# Altium schematic sheet Dental Sensor.SchDoc("Dental Sensor")
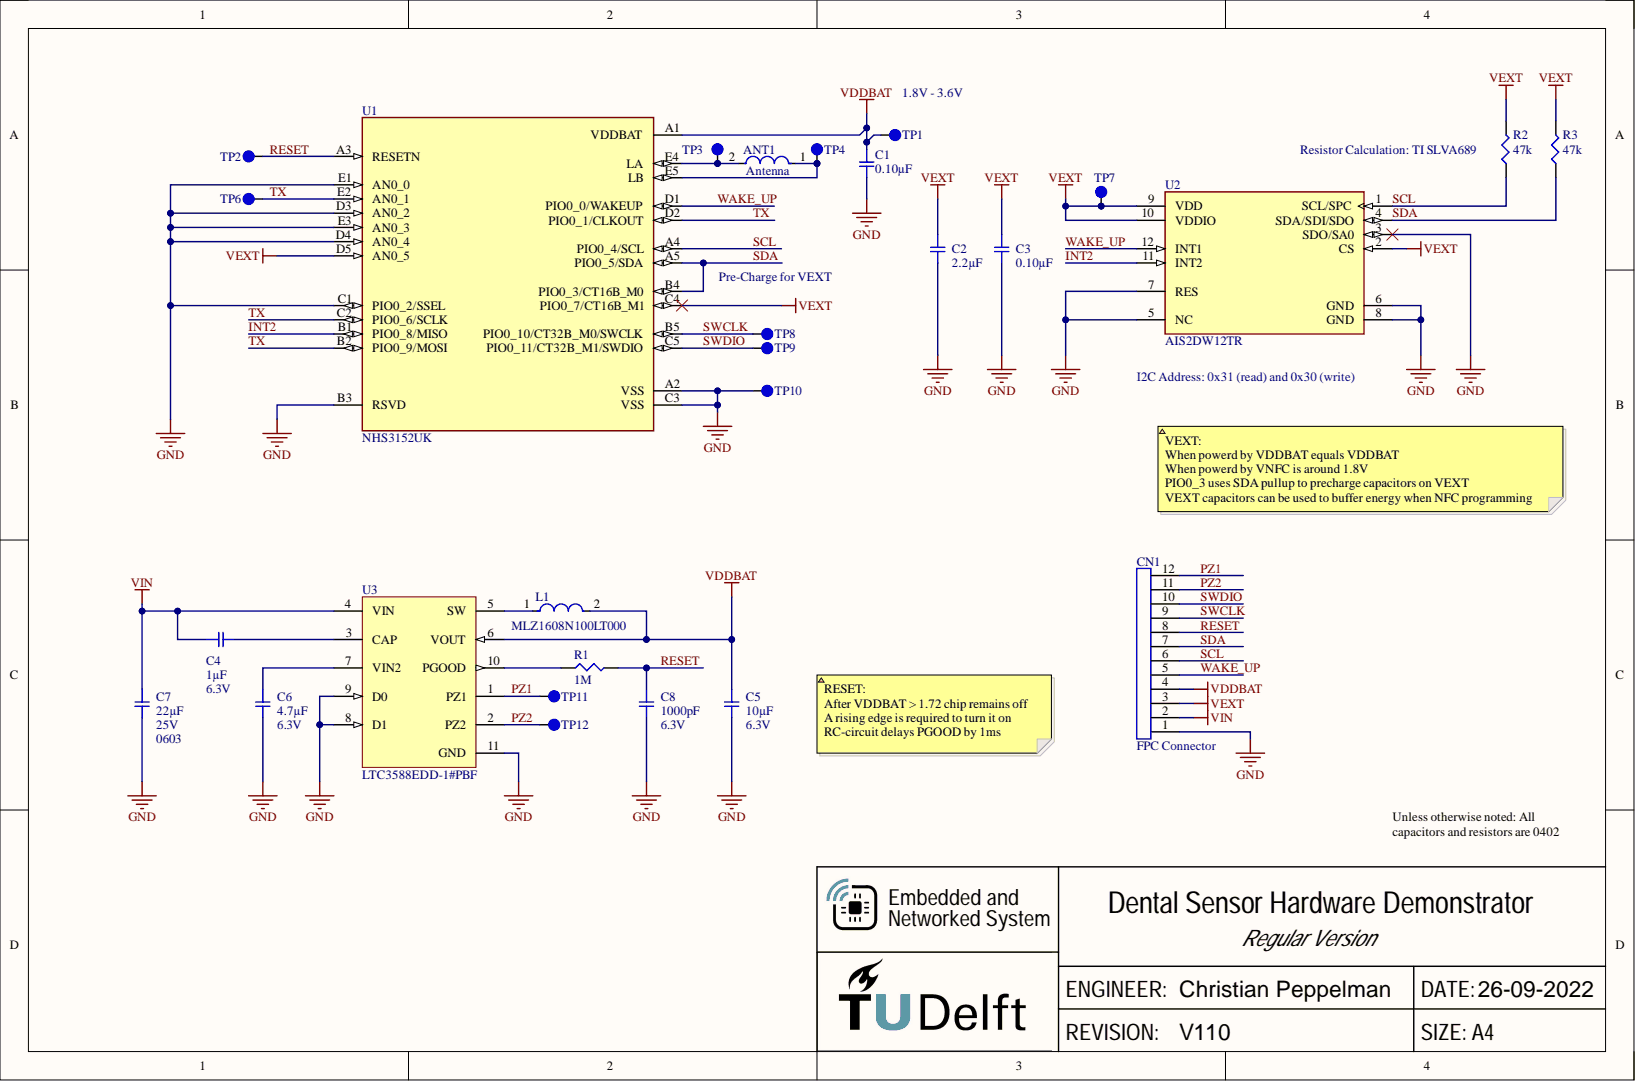
[diagram p0: Dental Sensor.SchDoc]

## Parts
ANT1  Antenna  [NFC Antenna]
C1  0.10µF  [CAPC1005X03N]
C2  2.2µF  [CAPC1005X03N]
C3  0.10µF  [CAPC1005X03N]
C4  1µF  [CAPC1005X03N]
C5  10µF  Voltage: 6.3V  [CAPC1005X03N]
C6  4.7µF  Voltage: 6.3V  [CAPC1005X03N]
C7  22µF  Package / Case: 0603  Voltage: 25V  [CAPC1608X12N]
C8  1000pF  Voltage: 6.3V  [CAPC1005X03N]
CN1  FPC Connector  [52745-1297]
L1  MLZ1608N100LT000  [FP-MLZ1608-MFG]
R1  1M  [RESC1005X03N]
R2  47k  [RESC1005X03N]
R3  47k  [RESC1005X03N]
TP1  Testpoint  [Testpoint 0.35mm]  (value not drawn)
TP2  Testpoint  [Testpoint 0.35mm]  (value not drawn)
TP3  Testpoint  [Testpoint 0.35mm]  (value not drawn)
TP4  Testpoint  [Testpoint 0.35mm]  (value not drawn)
TP6  Testpoint  [Testpoint 0.35mm]  (value not drawn)
TP7  Testpoint  [Testpoint 0.35mm]  (value not drawn)
TP8  Testpoint  [Testpoint 0.35mm]  (value not drawn)
TP9  Testpoint  [Testpoint 0.35mm]  (value not drawn)
TP10  Testpoint  [Testpoint 0.35mm]  (value not drawn)
TP11  Testpoint  [Testpoint 0.35mm]  (value not drawn)
TP12  Testpoint  [Testpoint 0.35mm]  (value not drawn)
U1  NHS3152UK  [WLCSP25]
U2  AIS2DW12TR  [FP-LGA12-DM00369449-MFG]
U3  LTC3588EDD-1#PBF  [LT-DD-10_V]

## Nets
GND: C1.2 C2.2 C3.2 C5.2 C6.2 C7.2 C8.2 CN1.1 TP10.1 U1.A2(VSS) U1.B3(RSVD) U1.C1 U1.C3(VSS) U1.D3(AN0_2) U1.D4(AN0_4) U1.E1(AN0_0) U1.E3(AN0_3) U2.3(SDO/SA0) U2.5(NC) U2.6(GND) U2.7(RES) U2.8(GND) U3.8(D1) U3.9(D0) U3.11(GND)
VDDBAT: C1.1 C5.1 CN1.4 L1.2 TP1.1 U1.A1(VDDBAT) U3.6(VOUT)
VEXT: C2.1 C3.1 CN1.3 R2.2 R3.2 TP7.1 U1.C4 U1.D5(AN0_5) U2.2(CS) U2.9(VDD) U2.10(VDDIO)
VIN: C4.1 C7.1 CN1.2 U3.4(VIN)
INT2: U1.B1 U2.11(INT2)
PZ1: CN1.12 TP11.1 U3.1(PZ1)
PZ2: CN1.11 TP12.1 U3.2(PZ2)
RESET: C8.1 CN1.8 R1.2 TP2.1 U1.A3(RESETN)
SCL: CN1.6 R2.1 U1.A4 U2.1(SCL/SPC)
SDA: CN1.7 R3.1 U1.A5 U1.B4 U2.4(SDA/SDI/SDO)
SWCLK: CN1.9 TP8.1 U1.B5
SWDIO: CN1.10 TP9.1 U1.C5
TX: TP6.1 U1.B2 U1.C2 U1.D2 U1.E2(AN0_1)
WAKE_UP: CN1.5 U1.D1 U2.12(INT1)
NetANT1_1: ANT1.1 TP4.1 U1.E5(LB)
NetANT1_2: ANT1.2 TP3.1 U1.E4(LA)
NetC4_2: C4.2 U3.3(CAP)
NetC6_1: C6.1 U3.7(VIN2)
NetL1_1: L1.1 U3.5(SW)
NetR1_1: R1.1 U3.10(PGOOD)

## Library data of the parts
ANT1 = NFC Antenna: Track 0.2; Gap 0.1; Height 4.6; Width 14.5;; Component Kind: Net Tie; Library Name: Library.SchLib
C1 C3 = GRM155R60J104KA01D: CAP CER 0.1UF 6.3V X5R 0402; Manufacturer: Murata Electronics North America; @ qty: 0; Minimum Quantity: 1; Tolerance: ±10%; Unit Price (USD): 0.1; Applications: General Purpose; Component Kind: Standard; Datasheets: http://www.murata.com/~/media/webrenewal/support/library/catalog/products/capacitor/mlcc/c02e.pdf; Digi-Key Part Number: 490-6287-1-ND; Factory Stock: 0; Features: -; Height - Seated (Max): -; Image: //media.digikey.com/Renders/Murata%20Renders/ZRB-SERIES-0402.jpg; Lead Spacing: -; Library Name: capacitor_murata_0402.IntLib; Mounting Type: Surface Mount, MLCC; Operating Temperature: -55°C ~ 85°C; Package / Case: 0402 (1005 Metric); Packaging: Cut Tape (CT); Part Number: GRM155R60J104KA01D; Part Status: Active; Quantity Available: 356062; Ratings: -; Series: GRM; Size / Dimension: 0.039" L x 0.020" W (1.00mm x 0.50mm); Temperature Coefficient: X5R; Thickness (Max): 0.022" (0.55mm); Value: 0.10µF; Voltage: 6.3V
C2 = GRT155R60J225KE01D: CAP CER 2.2UF 6.3V X5R 0402; Manufacturer: Murata Electronics North America; @ qty: 0; Minimum Quantity: 1; Tolerance: ±10%; Unit Price (USD): 0.12; Applications: Automotive; Component Kind: Standard; Datasheets: http://search.murata.co.jp/Ceramy/image/img/A01X/G101/ENG/GRT155R60J225KE01-01.pdf; Digi-Key Part Number: 490-12250-1-ND; Factory Stock: 0; Features: -; Height - Seated (Max): -; Image: //media.digikey.com/Renders/Murata%20Renders/ZRB-SERIES-0402.jpg; Lead Spacing: -; Lead Style: -; Library Name: capacitor_murata_0402.IntLib; Mounting Type: Surface Mount, MLCC; Operating Temperature: -55°C ~ 85°C; Package / Case: 0402 (1005 Metric); Packaging: Cut Tape (CT); Part Number: GRT155R60J225KE01D; Part Status: Active; Quantity Available: 39775; Ratings: AEC-Q200; Series: GRT; Size / Dimension: 0.039" L x 0.020" W (1.00mm x 0.50mm); Temperature Coefficient: X5R; Thickness (Max): 0.022" (0.55mm); Value: 2.2µF; Voltage: 6.3V
C4 = GRM155R70J105MA12D: CAP CER 1UF 6.3V X7R 0402; Manufacturer: Murata Electronics North America; @ qty: 0; Minimum Quantity: 1; Tolerance: ±20%; Unit Price (USD): 0.1; Applications: General Purpose; Component Kind: Standard; Datasheets: http://www.murata.com/~/media/webrenewal/support/library/catalog/products/capacitor/mlcc/c02e.pdf; Digi-Key Part Number: 490-9665-1-ND; Factory Stock: 0; Features: Derating Recommended; Height - Seated (Max): -; Image: //media.digikey.com/Renders/Murata%20Renders/ZRB-SERIES-0402.jpg; Lead Spacing: -; Lead Style: -; Library Name: capacitor_murata_0402.IntLib; Mounting Type: Surface Mount, MLCC; Operating Temperature: -55°C ~ 125°C; Package / Case: 0402 (1005 Metric); Packaging: Cut Tape (CT); Part Number: GRM155R70J105MA12D; Part Status: Active; Quantity Available: 38208; Ratings: -; Series: GRM; Size / Dimension: 0.039" L x 0.020" W (1.00mm x 0.50mm); Temperature Coefficient: X7R; Thickness (Max): 0.022" (0.55mm); Value: 1µF; Voltage: 6.3V
C5 = GRM155R60J106ME44D: CAP CER 10UF 6.3V X5R 0402; Manufacturer: Murata Electronics North America; @ qty: 0; Minimum Quantity: 1; Tolerance: ±20%; Unit Price (USD): 0.23; Applications: General Purpose; Component Kind: Standard; Datasheets: http://www.murata.com/~/media/webrenewal/support/library/catalog/products/capacitor/mlcc/c02e.pdf; Digi-Key Part Number: 490-13811-1-ND; Factory Stock: 0; Features: -; Height - Seated (Max): -; Image: //media.digikey.com/Renders/Murata%20Renders/ZRB-SERIES-0402.jpg; Lead Spacing: -; Lead Style: -; Library Name: capacitor_murata_0402.IntLib; Mounting Type: Surface Mount, MLCC; Operating Temperature: -55°C ~ 85°C; Package / Case: 0402 (1005 Metric); Packaging: Cut Tape (CT); Part Number: GRM155R60J106ME44D; Part Status: Active; Quantity Available: 191464; Ratings: -; Series: GRM; Size / Dimension: 0.039" L x 0.020" W (1.00mm x 0.50mm); Temperature Coefficient: X5R; Thickness (Max): 0.022" (0.55mm); Value: 10µF
C6 = ZRB15XR60J475ME01D: CAP CER 4.7UF 6.3V X5R 0402; Manufacturer: Murata Electronics North America; @ qty: 0; Minimum Quantity: 1; Tolerance: ±20%; Unit Price (USD): 0.36; Applications: Acoustic Noise Reduction; Component Kind: Standard; Datasheets: http://search.murata.co.jp/Ceramy/image/img/A01X/G101/ENG/ZRB15XR60J475ME01-01.pdf; Digi-Key Part Number: 490-10983-1-ND; Factory Stock: 0; Features: Interposer Termination; Height - Seated (Max): -; Image: //media.digikey.com/Renders/Murata%20Renders/ZRB-SERIES-0402.jpg; Lead Spacing: -; Lead Style: -; Library Name: capacitor_murata_0402.IntLib; Mounting Type: Surface Mount, MLCC; Operating Temperature: -55°C ~ 85°C; Package / Case: 0402 (1005 Metric); Packaging: Cut Tape (CT); Part Number: ZRB15XR60J475ME01D; Part Status: Active; Quantity Available: 10846; Ratings: -; Series: ZRB; Size / Dimension: 0.039" L x 0.020" W (1.00mm x 0.50mm); Temperature Coefficient: X5R; Thickness (Max): 0.031" (0.80mm); Value: 4.7µF
C7 = GRM187R61A226ME15D: CAP CER 22UF 10V X5R 0603; Manufacturer: Murata Electronics North America; @ qty: 0; Minimum Quantity: 1; Tolerance: ±20%; Unit Price (USD): 0.54; Applications: General Purpose; Component Kind: Standard; Datasheets: http://psearch.en.murata.com/capacitor/product/GRM187R61A226ME15%23.pdf; Digi-Key Part Number: 490-13243-1-ND; Factory Stock: 0; Features: Derating Recommended; Height - Seated (Max): -; Image: //media.digikey.com/Renders/Murata%20Renders/ZRB-SERIES-0402.jpg; Lead Spacing: -; Lead Style: -; Library Name: capacitor_murata_0603.IntLib; Mounting Type: Surface Mount, MLCC; Operating Temperature: -55°C ~ 85°C; Packaging: Cut Tape (CT); Part Number: GRM187R61A226ME15D; Part Status: Active; Quantity Available: 10253; Ratings: -; Series: GRM; Size / Dimension: 0.063" L x 0.031" W (1.60mm x 0.80mm); Temperature Coefficient: X5R; Thickness (Max): 0.031" (0.80mm); Value: 22µF
C8 = GRM155R60J102KA01D: CAP CER 1000PF 6.3V X5R 0402; Manufacturer: Murata Electronics North America; @ qty: 0; Minimum Quantity: 1; Tolerance: ±10%; Unit Price (USD): 0.1; Applications: General Purpose; Component Kind: Standard; Datasheets: http://www.murata.com/~/media/webrenewal/support/library/catalog/products/capacitor/mlcc/c02e.pdf; Digi-Key Part Number: 490-6285-1-ND; Factory Stock: 0; Features: -; Height - Seated (Max): -; Image: //media.digikey.com/Renders/Murata%20Renders/ZRB-SERIES-0402.jpg; Lead Spacing: -; Library Name: capacitor_murata_0402.IntLib; Mounting Type: Surface Mount, MLCC; Operating Temperature: -55°C ~ 85°C; Package / Case: 0402 (1005 Metric); Packaging: Cut Tape (CT); Part Number: GRM155R60J102KA01D; Part Status: Active; Quantity Available: 65829; Ratings: -; Series: GRM; Size / Dimension: 0.039" L x 0.020" W (1.00mm x 0.50mm); Temperature Coefficient: X5R; Thickness (Max): 0.022" (0.55mm); Value: 1000pF
CN1 = 52745-1297: FFC / FPC Board Connector, 0.5 mm, 12 Contacts, Receptacle, Easy-On 52745, Surface Mount, Top; Component Kind: Standard; Library Name: Library.SchLib
L1 = CMP-08253-000255-1: Shielded Multilayer Inductor 10uH ±20% 300mA 780mΩ SMD 0603; Core Material: Ferrite; DC Resistance (DCR): 780mR; Saturation Current: 60mA; Self Resonant Frequency: 50MHz; Test Frequency: 2MHz; Case Code (Imperial): 0603; Case Code (Metric): 1608; Case/Package: 0603; Component Kind: Standard; Current Rating: 300mA; Height - Seated (Max): 0.037inch; Inductance: 10uH; Lead Free: Lead Free; Length: 0.063inch; Library Name: Altium Content Vault; Max Operating Temperature: 125°C; Min Operating Temperature: -55°C; Mount: Surface Mount; Package Quantity: 4000; Packaging: Tape and Reel; RoHS Compliant: Yes; Shielding: Shielded; Tolerance: 20%; Width: 0.031inch
R1 = ERJ-2RKF1004X: RES SMD 1M OHM 1% 1/10W 0402; Manufacturer: Panasonic Electronic Components; @ qty: 1500; Factory Stock: 0; Minimum Quantity: 1; Power (Watts): 0.1W, 1/10W; Temperature Coefficient: ±100ppm/°C; Unit Price (USD): 0.00496; Component Kind: Standard; Composition: Thick Film; Datasheets: http://industrial.panasonic.com/www-cgi/jvcr13pz.cgi?E+PZ+3+AOA0002+ERJ2RKF1004X+7+WW; Digi-Key Part Number: P1.00MLCT-ND; Failure Rate: -; Features: Automotive AEC-Q200; Height - Seated (Max): 0.016" (0.40mm); Image: //media.digikey.com/Photos/Panasonic%20Photos/ERJ-PA2-Series.jpg; Library Name: Panasonic_ERJ_0402.IntLib; Number of Terminations: 2; Operating Temperature: -55°C ~ 155°C; Package / Case: 0402 (1005 Metric); Packaging: Cut Tape (CT); Part Number: ERJ-2RKF1004X; Part Status: Active; Quantity Available: 773705; Series: ERJ; Size / Dimension: 0.039" L x 0.020" W (1.00mm x 0.50mm); Supplier Device Package: 0402; Tolerance: ±1%; Value: 1M
R2 R3 = ERJ-2RKF4702X: RES SMD 47K OHM 1% 1/10W 0402; Manufacturer: Panasonic Electronic Components; @ qty: 1500; Factory Stock: 0; Minimum Quantity: 1; Power (Watts): 0.1W, 1/10W; Temperature Coefficient: ±100ppm/°C; Unit Price (USD): 0.00496; Component Kind: Standard; Composition: Thick Film; Datasheets: http://industrial.panasonic.com/www-cgi/jvcr13pz.cgi?E+PZ+3+AOA0002+ERJ2RKF4702X+7+WW; Digi-Key Part Number: P47.0KLCT-ND; Failure Rate: -; Features: Automotive AEC-Q200; Height - Seated (Max): 0.016" (0.40mm); Image: //media.digikey.com/Photos/Panasonic%20Photos/ERJ-PA2-Series.jpg; Library Name: Panasonic_ERJ_0402.IntLib; Number of Terminations: 2; Operating Temperature: -55°C ~ 155°C; Package / Case: 0402 (1005 Metric); Packaging: Cut Tape (CT); Part Number: ERJ-2RKF4702X; Part Status: Active; Quantity Available: 230515; Series: ERJ; Size / Dimension: 0.039" L x 0.020" W (1.00mm x 0.50mm); Supplier Device Package: 0402; Tolerance: ±1%; Value: 47k
U1 = NHS3152UK: ARM-microcontrollers - MCU NTAG NHS3152 NFC; Component Kind: Standard; Library Name: Library.SchLib
U2 = CMP-12175-000014-1: MEMS DIGITAL OUTPUT MOTION SENSO; Component Kind: Standard; Library Name: Altium Content Vault; RoHS Compliant: Yes
U3 = CMP-0473-00034-1: Piezoelectric Energy Harvesting Power Supply, 10-pin SON (DD-10), -40 to 125 degC, Pb-Free; Manufacturer: Linear Technology; Number of Outputs: 1; Topology: Buck; Vin Max(V): 20; Vin Min(V): 2.7; Vout Max(V): 1.8, 2.5, 3.3, 3.6; Code_JEDEC: MO-229; Component Kind: Standard; ComponentLink1Description: Manufacturer URL; ComponentLink1URL: http://www.linear.com/; ComponentLink2Description: Datasheet; ComponentLink2URL: http://cds.linear.com/docs/en/datasheet/35881fa.pdf; ComponentLink3Description: Package Specification; ComponentLink3URL: http://cds.linear.com/docs/en/packaging/DFN_10_05-08-1699.pdf; DatasheetVersion: Rev. A; Energy Source: Piezoelectric; Library Name: Altium Content Vault; Mounting Technology: Surface Mount; PackageDescription: 10-Pin Plastic DFN, Body 3 x 3 mm, Pitch 0.5 mm; PackageReference: DD-10; PackageVersion: Rev. C, 06/2010; PartNumber: LTC3588EDD-1#PBF; RoHS: TRUE
TP1-TP4 TP6-TP12 = Testpoint: Component Kind: Standard; Library Name: Library.SchLib
